# Altium schematic sheet SH5_BI-Gr_Potentiostat_ANA_proc_R0.05.00.SchDoc(SH5_BI-Gr_Potentiostat_ANA_proc_R0.05.00)
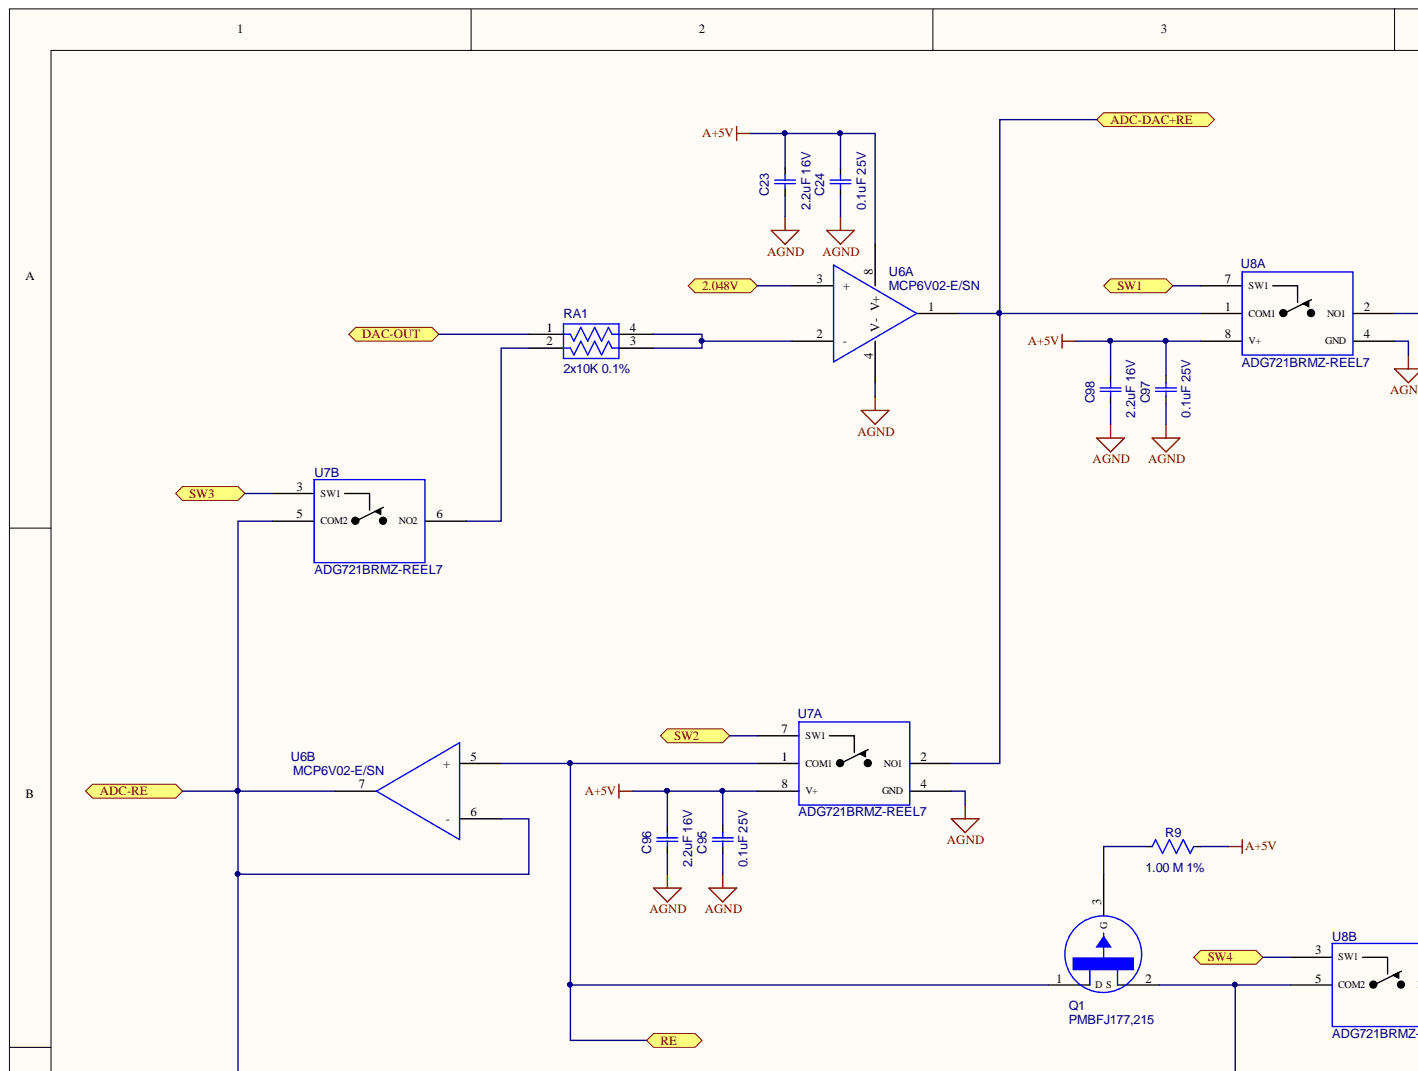
[diagram p0: SH5_BI-Gr_Potentiostat_ANA_proc_R0.05.00.SchDoc, top left]
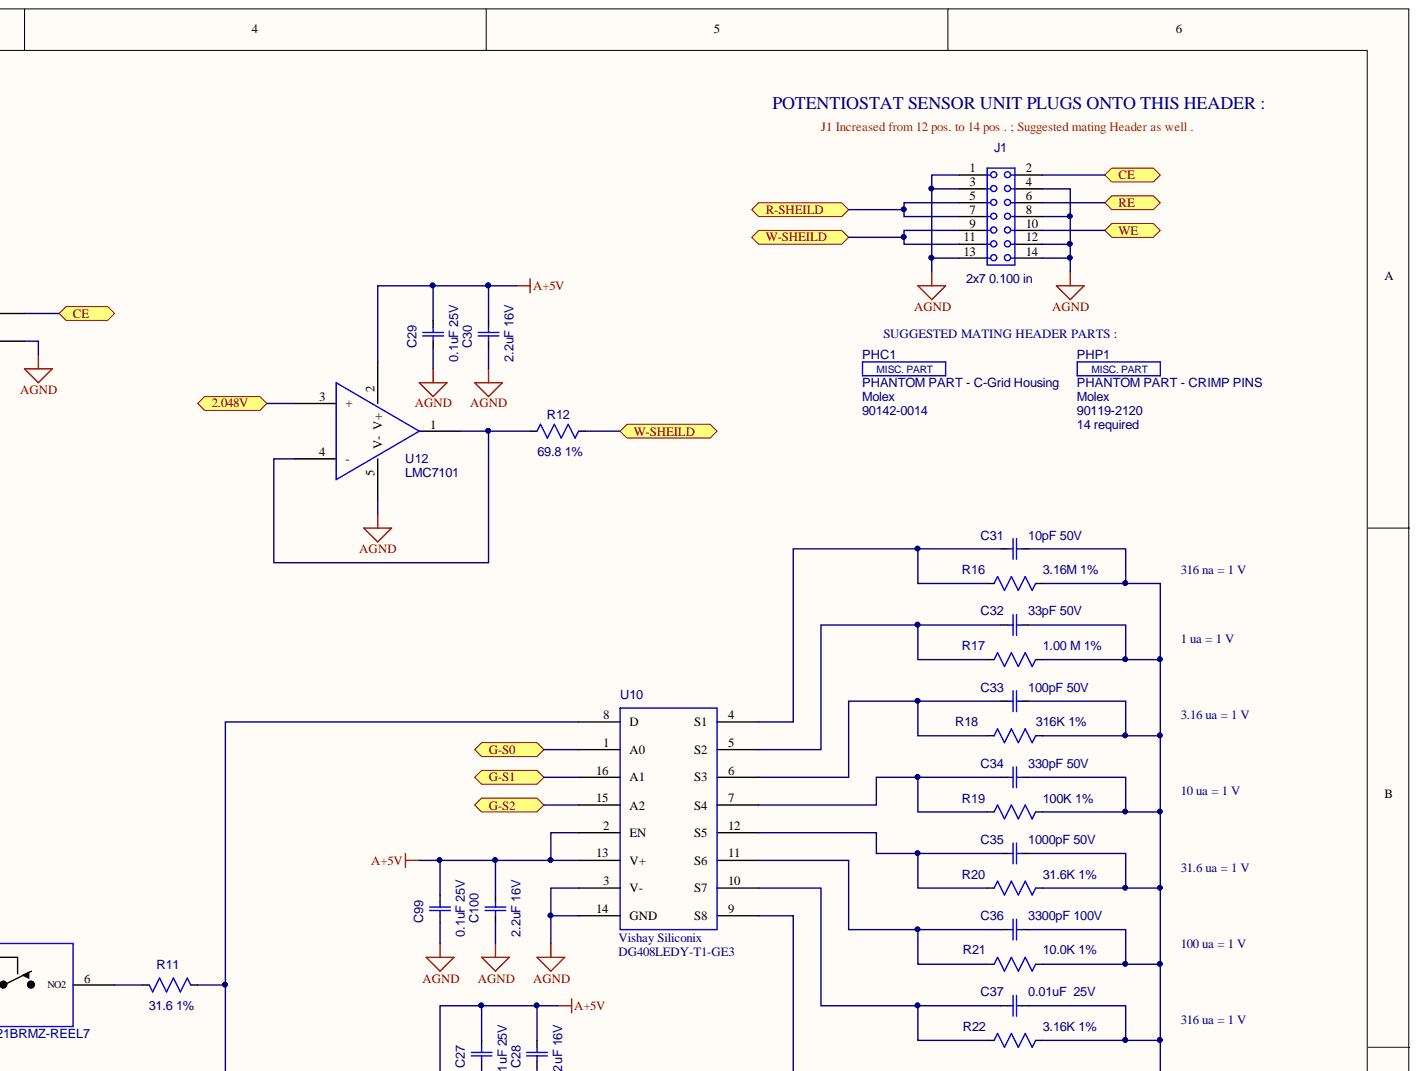
[diagram p1: SH5_BI-Gr_Potentiostat_ANA_proc_R0.05.00.SchDoc, top right]
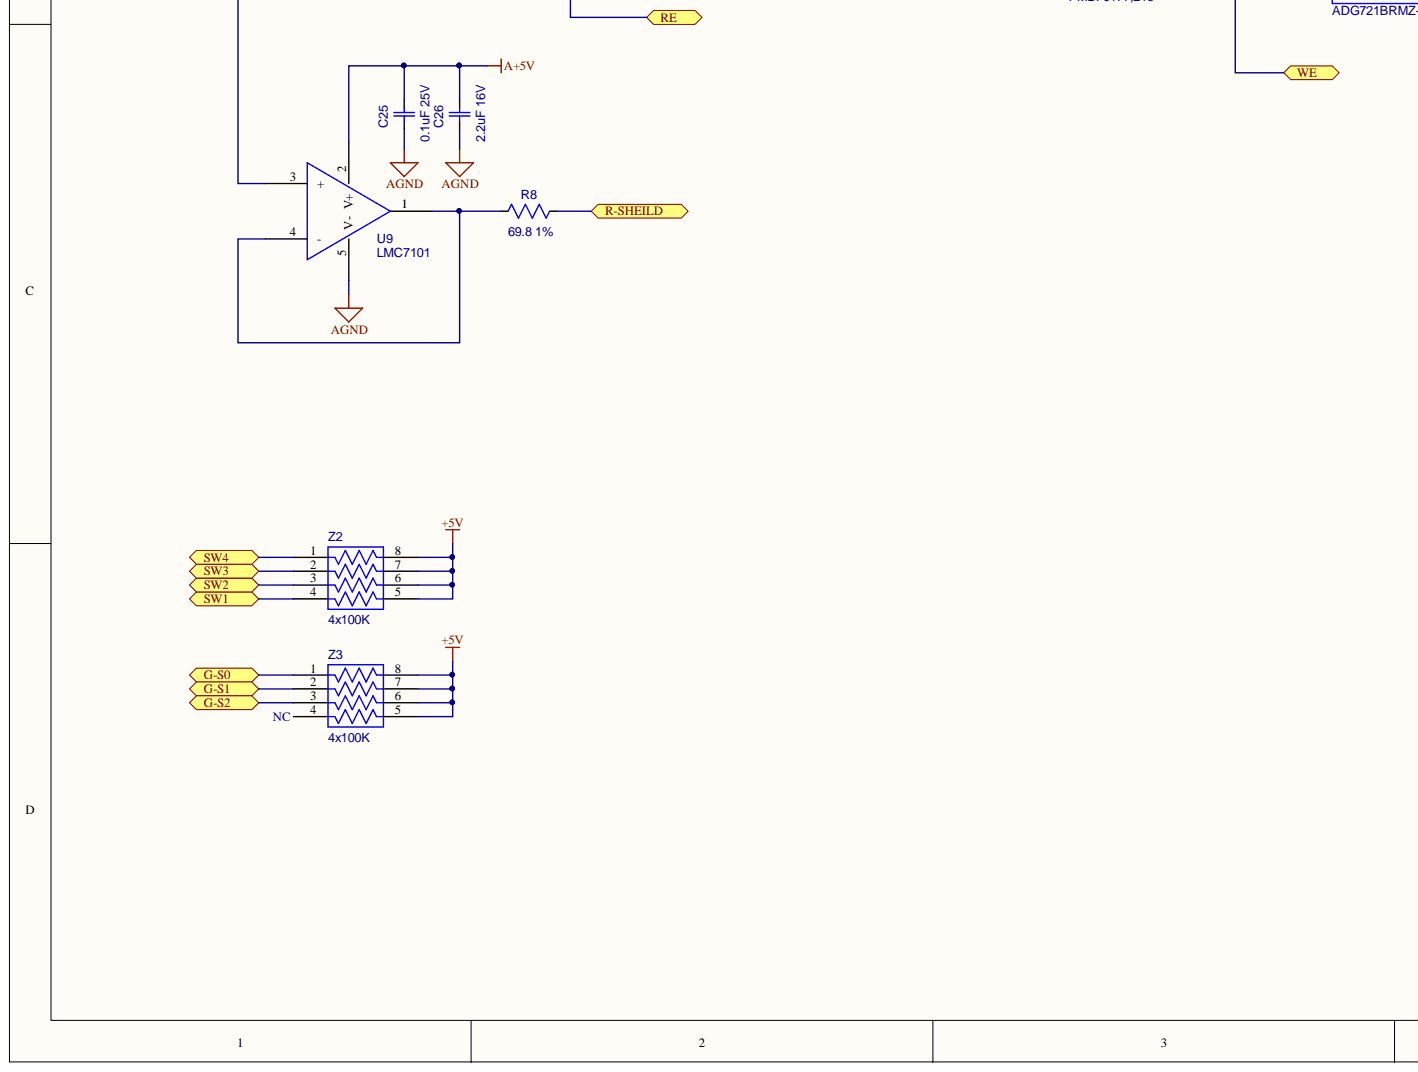
[diagram p2: SH5_BI-Gr_Potentiostat_ANA_proc_R0.05.00.SchDoc, bottom left]
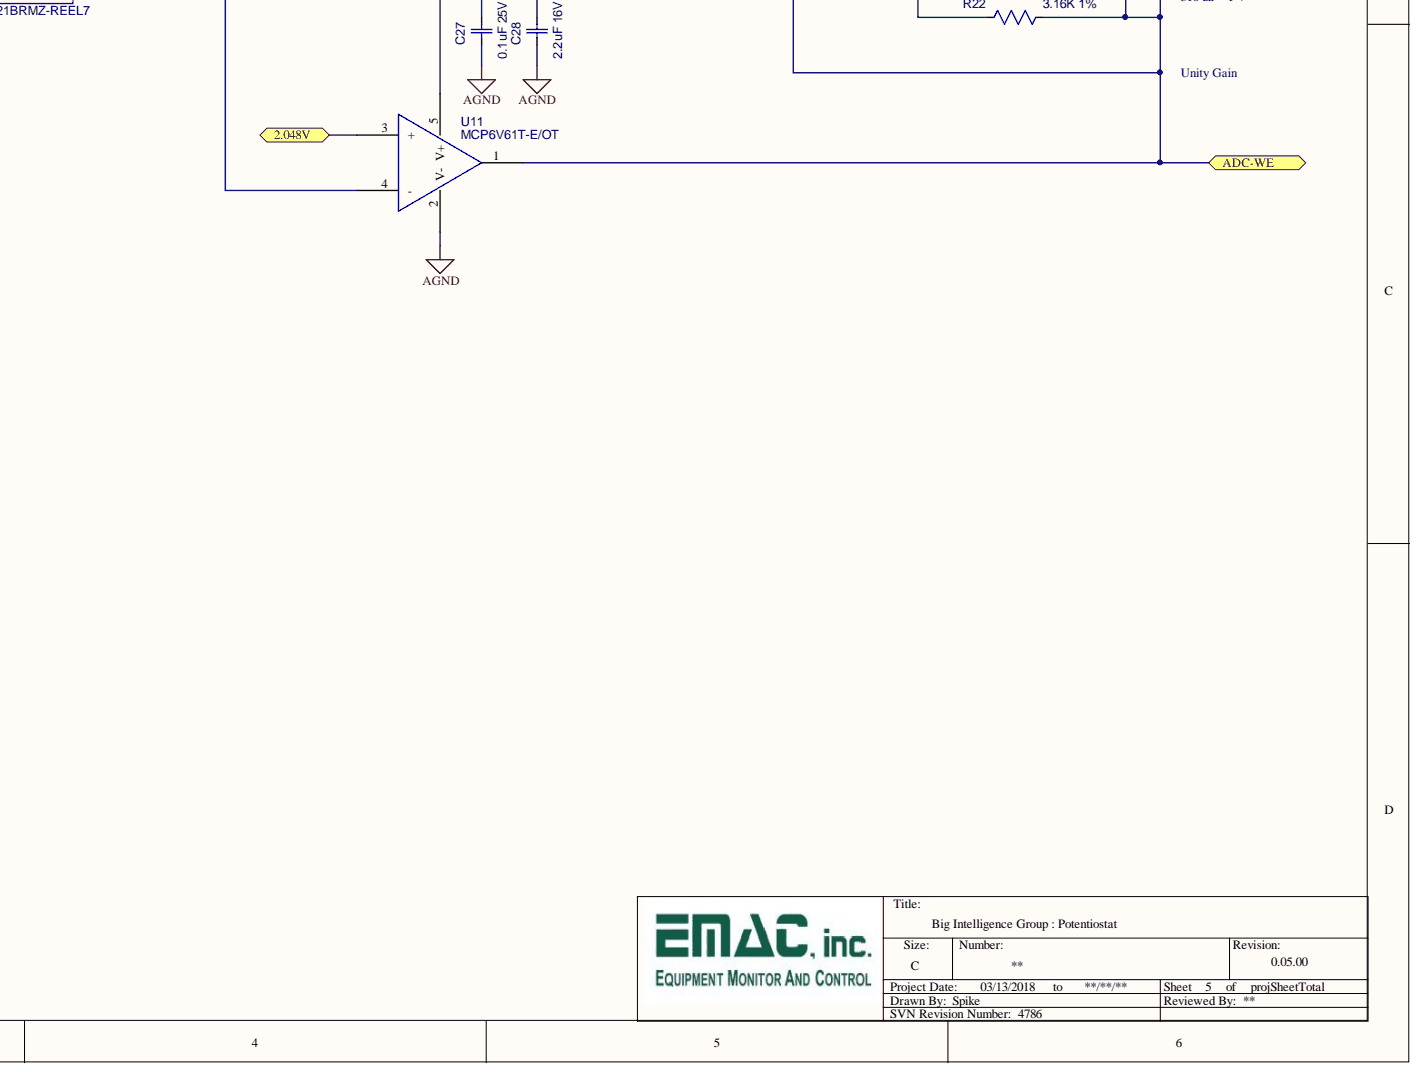
[diagram p3: SH5_BI-Gr_Potentiostat_ANA_proc_R0.05.00.SchDoc, bottom right]

## Parts
C23  2.2uF 16V  value 2.2uF  Notes: 16V  [CC0603_IPC_L]
C24  0.1uF 25V  Notes: 25V  [CC0603_IPC_L]
C25  0.1uF 25V  Notes: 25V  [CC0603_IPC_L]
C26  2.2uF 16V  value 2.2uF  Notes: 16V  [CC0603_IPC_L]
C27  0.1uF 25V  Notes: 25V  [CC0603_IPC_L]
C28  2.2uF 16V  value 2.2uF  Notes: 16V  [CC0603_IPC_L]
C29  0.1uF 25V  Notes: 25V  [CC0603_IPC_L]
C30  2.2uF 16V  value 2.2uF  Notes: 16V  [CC0603_IPC_L]
C31  10pF 50V  value 10pF  Notes: 50V  [CC0603_IPC_L]
C32  33pF 50V  value 33pF  Notes: 50V  [CC0603_IPC_L]
C33  100pF 50V  value 100pF  Notes: 50V  [CC0603_IPC_L]
C34  330pF 50V  value 330pF  Notes: 50V  [CC0603_IPC_L]
C35  1000pF 50V  value 1000pF  Notes: 50V  [CC0603_IPC_L]
C36  3300pF 100V  value 3300pF  Notes: 100V  [CC0603_IPC_L]
C37  0.01uF  25V  value 0.01uF  Notes: 25V  [CC0603_IPC_L]
C95  0.1uF 25V  Notes: 25V  [CC0603_IPC_L]
C96  2.2uF 16V  value 2.2uF  Notes: 16V  [CC0603_IPC_L]
C97  0.1uF 25V  Notes: 25V  [CC0603_IPC_L]
C98  2.2uF 16V  value 2.2uF  Notes: 16V  [CC0603_IPC_L]
C99  0.1uF 25V  Notes: 25V  [CC0603_IPC_L]
C100  2.2uF 16V  value 2.2uF  Notes: 16V  [CC0603_IPC_L]
J1  Notes: 2x7 0.100 in  [CHDR14_PAT2]  (hidden comment: CHDR14)
PHC1  PHANTOM PART - C-Grid Housing  Manfacturer PN: 90142-0014  Manfacturer: Molex  [PHANTOM_PAT1]
PHP1  PHANTOM PART - CRIMP PINS  Manfacturer PN: 90119-2120  Manfacturer: Molex  [PHANTOM_PAT1]
Q1  PMBFJ177,215  Type: S  [SOT95P230X110-3N]
R8  69.8 1%  [RC0603_IPC_L]
R9  1.00 M 1%  [RC0603_IPC_L]
R11  31.6 1%  [RC0603_IPC_L]
R12  69.8 1%  [RC0603_IPC_L]
R16  3.16M 1%  [RC0603_IPC_L]
R17  1.00 M 1%  [RC0603_IPC_L]
R18  316K 1%  [RC0603_IPC_L]
R19  100K 1%  [RC0603_IPC_L]
R20  31.6K 1%  [RC0603_IPC_L]
R21  10.0K 1%  [RC0603_IPC_L]
R22  3.16K 1%  [RC0603_IPC_L]
RA1  2x10K 0.1%  [RNET_ACAS0606_X2_PAT]
U6  MCP6V02  Manufacturer PN: MCP6V02-E/SN  [SOIC127P600X175-8N]  (parts A,B)
U7  ADG721  Manufacturer PN: ADG721BRMZ-REEL7  [SOP65P490X110-8N]  (parts A,B)
U8  ADG721  Manufacturer PN: ADG721BRMZ-REEL7  [SOP65P490X110-8N]  (parts A,B)
U9  LMC7101  [SOT23_5_LMC7101]
U10  DG408  Manufacturer PN: DG408LEDY-T1-GE3  Manufacturer: Vishay Siliconix  [SOIC127P600X165-16N]
U11  MCP6V61T-E/OT  [SOT23-5_MCP6V61]
U12  LMC7101  [SOT23_5_LMC7101]
Z2  4x100K  [RNET_CAT10-0804_X4_PAT]
Z3  4x100K  [RNET_CAT10-0804_X4_PAT]

## Ports
2.048V, ADC-DAC+RE, ADC-RE, ADC-WE, CE, DAC-OUT, G-S0, G-S1, G-S2, R-SHEILD, RE, SW1, SW2, SW3, SW4, W-SHEILD, WE

## Nets
+5V: Z2.5 Z2.6 Z2.7 Z2.8 Z3.5 Z3.6 Z3.7 Z3.8
A+5V: C23.1 C24.1 C25.1 C26.1 C27.1 C28.1 C29.1 C30.1 C95.1 C96.1 C97.1 C98.1 C99.1 C100.1 R9.2 U6.8(V+) U7.8(V+) U8.8(V+) U9.2(V+) U10.2(EN) U10.13(V+) U11.5(V+) U12.2(V+)
AGND: C23.2 C24.2 C25.2 C26.2 C27.2 C28.2 C29.2 C30.2 C95.2 C96.2 C97.2 C98.2 C99.2 C100.2 J1.1 J1.3 J1.4 J1.8 J1.12 J1.13 J1.14 U6.4(V-) U7.4(GND) U8.4(GND) U9.5(V-) U10.3(V-) U10.14(GND) U11.2(V-) U12.5(V-)
2.048V: U6.3(+) U11.3(+) U12.3(+) ; port 2.048V
ADC-DAC+RE: U6.1 U7.2(NO1) U8.1(COM1) ; port ADC-DAC+RE
ADC-RE: U6.6(-) U6.7 U7.5(COM2) U9.3(+) ; port ADC-RE
ADC-WE: C31.2 C32.2 C33.2 C34.2 C35.2 C36.2 C37.2 R16.2 R17.2 R18.2 R19.2 R20.2 R21.2 R22.2 U10.9(S8) U11.1 ; port ADC-WE
CE: J1.2 U8.2(NO1) ; port CE
DAC-OUT: RA1.1 ; port DAC-OUT
G-S0: U10.1(A0) Z3.1 ; port G-S0
G-S1: U10.16(A1) Z3.2 ; port G-S1
G-S2: U10.15(A2) Z3.3 ; port G-S2
R-SHEILD: J1.5 J1.7 R8.2 ; port R-SHEILD
RE: J1.6 Q1.1 U6.5(+) U7.1(COM1) ; port RE
SW1: U8.7(SW1) Z2.4 ; port SW1
SW2: U7.7(SW1) Z2.3 ; port SW2
SW3: U7.3(SW1) Z2.2 ; port SW3
SW4: U8.3(SW1) Z2.1 ; port SW4
W-SHEILD: J1.9 J1.11 R12.2 ; port W-SHEILD
WE: J1.10 Q1.2 U8.5(COM2) ; port WE
NetC31_1: C31.1 R16.1 U10.4(S1)
NetC32_1: C32.1 R17.1 U10.5(S2)
NetC33_1: C33.1 R18.1 U10.6(S3)
NetC34_1: C34.1 R19.1 U10.7(S4)
NetC35_1: C35.1 R20.1 U10.12(S5)
NetC36_1: C36.1 R21.1 U10.11(S6)
NetC37_1: C37.1 R22.1 U10.10(S7)
NetQ1_3: Q1.3 R9.1
NetR8_1: R8.1 U9.1 U9.4(-)
NetR11_1: R11.1 U10.8(D) U11.4(-)
NetR11_2: R11.2 U8.6(NO2)
NetR12_1: R12.1 U12.1 U12.4(-)
NetRA1_2: RA1.2 U7.6(NO2)
NetRA1_3: RA1.3 RA1.4 U6.2(-)

Not connected: Z3 4

## Library data of the parts
C23 C26 C28 C30 C96 C98 C100 = 09-90070: CCG^  2.2uF  16V 0603  10% X5R; type: S; SVN Revision No.: 5061; Status Code: L; Options: 00; Database Table Name: passives; Part Number: 09-90070; Package: 0603; msl: 1; Library Name: MySQL_ALTIUM.DbLib; Height Max (mm): 0.90 mm; Component Kind: Standard; Author: Mike L.
C24 C25 C27 C29 C95 C97 C99 = 09-90081: CCG^0.1uF   25V 0603   10% X7R; Manufacturer PN: C0603C104K3RAC7411; Manufacturer: Kemet; Value: .1 uF; type: S; Temp Range (°C): -55°C ~ 125°C; SVN Revision No.: 5061; Status Code: L; Options: 00; Database Table Name: passives; RoHS: YES; Part Number: 09-90081; Package: 0603; msl: 1; Library Name: MySQL_ALTIUM.DbLib; Height Max (mm): 0.90 mm; Component Kind: Standard; Author: Mike L.
C31 = 09-90019: CCG^10pF   50V 0603    5% C0G; type: S; SVN Revision No.: 5061; Status Code: L; Options: 00; Database Table Name: passives; Part Number: 09-90019; Package: 0603; msl: 1; Library Name: MySQL_ALTIUM.DbLib; Height Max (mm): 0.87 mm; Component Kind: Standard; Author: Mike L.
C32 = 09-90175: CCG^33pF 50V 0603 5% C0G; Manufacturer PN: CC0603JRNPO9BN330; Manufacturer: Yageo; type: S; Temp Range (°C): -55°C ~ 125°C; SVN Revision No.: 5061; Status Code: N; Options: 00; Database Table Name: passives; RoHS: YES; Part Number: 09-90175; Package: 0603; msl: 1; Library Name: MySQL_ALTIUM.DbLib; Last Updated: 11/27/17; Height Max (mm): 0.90 mm; Datasheet: http://www.yageo.com/documents/recent/UPY-GP_NP0_16V-to-50V_16.pdf; Component Kind: Standard; Author: JP
C33 = 09-90016: CCG^100pF   50V 0603    5% C0G; Manufacturer PN: C0603C101J5GACTU; Manufacturer: Kemet; type: S; Temp Range (°C): -55°C ~ 125°C; SVN Revision No.: 5061; Status Code: L; Options: 00; Database Table Name: passives; Part Number: 09-90016; Package: 0603; msl: 1; Library Name: MySQL_ALTIUM.DbLib; Height Max (mm): 0.87 mm; Component Kind: Standard; Author: Mike L.
C34 = 09-90023: CCG^330pF   50V 0603   10% X7R; type: S; SVN Revision No.: 5061; Status Code: L; Options: 00; Database Table Name: passives; Part Number: 09-90023; Package: 0603; msl: 1; Library Name: MySQL_ALTIUM.DbLib; Height Max (mm): 0.90 mm; Component Kind: Standard; Author: Mike L.
C35 = 09-90013: CCG^1000PF  50V 0603   10% X7R; Manufacturer PN: GRM188R71H102KA01D; Manufacturer: Murata; type: S; Temp Range (°C): -55°C ~ 125°C; SVN Revision No.: 5061; Status Code: L; Options: 00; Database Table Name: passives; RoHS: YES; Part Number: 09-90013; Package: 0603; msl: 1; Library Name: MySQL_ALTIUM.DbLib; Height Max (mm): 0.90 mm; Datasheet: http://search.murata.co.jp/Ceramy/image/img/w_hinm/L0005E.pdf; Component Kind: Standard; Author: Mike L.
C36 = 09-90037: CCG^3300pF 1000V 0603 5% X7R; Manufacturer PN: 06031C332JAT2A; Manufacturer: AVX Corporation; type: S; Temp Range (°C): -55°C ~ 125°C; SVN Revision No.: 5061; Status Code: N; Options: 00; Database Table Name: passives; RoHS: YES; Part Number: 09-90037; Package: 0603; msl: 1; Library Name: MySQL_ALTIUM.DbLib; Height Max (mm): 0.90 mm; Datasheet: http://datasheets.avx.com/X7RDielectric.pdf; Component Kind: Standard; Author: TM
C37 = 09-90060: CCG^0.01uF  25V 0603    5% C0G; Manufacturer PN: C1608C0G1E103J080AA; Manufacturer: TDK Corporation; type: S; Temp Range (°C): -55°C ~ 125°C; SVN Revision No.: 5061; Status Code: L; Options: 00; Database Table Name: passives; RoHS: YES; Part Number: 09-90060; Package: 0603; msl: 1; Library Name: MySQL_ALTIUM.DbLib; Height Max (mm): 0.95 mm; Component Kind: Standard; Author: Mike L.
J1 = 20-0314: NHD^MPE 2X7 0.1" GOLD PRE; Manufacturer: EMAC inc.; Value: {Value}; Temp Range (°C): {Temp Range}; SVN Revision No.: 558; Status Code: L; Options: 00; Database Table Name: connectors; Type: M; RoHS: YES; Part Number: 20-0314; MSL: N/A; Library Name: MySQL_ALTIUM.DbLib; Height Max (mm): 8.50 mm; Component Kind: Standard; ComponentHeight: {ComponentHeight}; Author: ML
PHC1 = 26: PHANTOM PART W/O PNP; Part Number: 00-NON-INVNTORY; SVN Revision No.: 2917; Options: 98; Library Name: MySQL_ALTIUM.DbLib; id: 26; Database Table Name: phantom; Component Kind: Standard; Author: {Author}
PHP1 = 26: PHANTOM PART W/O PNP; Part Number: 00-NON-INVNTORY; SVN Revision No.: 2917; Options: 98; Notes: 14 required; Library Name: MySQL_ALTIUM.DbLib; id: 26; Database Table Name: phantom; Component Kind: Standard; Author: {Author}
Q1 = 05-90036: TJP^30V 0.3W J177 SOT23; Manufacturer: NXP USA Inc.; Value: 30V, 300mW; Temp Range (°C): -65°C ~ 150°C; SVN Revision No.: VersionControl; Status Code: N; Options: 00; Notes: Vgs(off)=0.8V ~ 2.25V, Ids=1.5mA ~ 20mA, Rds(on) = 300ohm; Database Table Name: actives; ROHS: YES; Part Number: 05-90036; Package: SOT23-3; MSL: 1; Manufacturer PN: PMBFJ177,215; Library Name: MySQL_ALTIUM.DbLib; Height Max (mm): 1.10 mm; Datasheet: http://www.nxp.com/documents/data_sheet/PMBFJ174_175_176_177_CNV.pdf; Component Kind: Standard; Author: TM
R8 R12 = 10-90453: RCP^  69.8 ohm 1% 0.100W  0603; Manufacturer PN: RC0603FR-0769R8L; Manufacturer: Yageo; Temp Range (°C): -55°C ~ 155°C; SVN Revision No.: 3043; Status Code: N; Options: 00; Notes: Moisture Resistant Thick Film; Database Table Name: resistors; Value: 69.8 1%; Type: S; RoHS: YES; Part Number: 10-90453; Package: 0603; MSL: 1; Library Name: MySQL_ALTIUM.DbLib; Last Updated: 3/20/18; Height Max (mm): 0.55 mm; Datasheet: http://www.yageo.com/documents/recent/PYu-RC_Group_51_RoHS_L_9.pdf; Component Kind: Standard; Author: JP
R9 R17 = 10-90061: RCP^ 1M ohm 1% 0.100W 0603; Manufacturer PN: RC0603FR-071ML; Manufacturer: Yageo; Value: 1M 1%; Temp Range (°C): -55°C ~ 155°C; SVN Revision No.: 3043; Status Code: L; Options: 00; Database Table Name: resistors; Type: S; RoHS: YES; Part Number: 10-90061; Package: 0603; MSL: 1; Library Name: MySQL_ALTIUM.DbLib; Height Max (mm): 0.55 mm; Datasheet: http://www.yageo.com.tw/exep/pages/download/literatures/PYu-R_INT-thick_7.pdf; Component Kind: Standard; Author: Mike L.
R11 = 10-90455: RCP^  31.6 ohm 1% 0.100W  0603; Manufacturer PN: ERJ-3EKF31R6V; Manufacturer: Panasonic Electronic Components; Temp Range (°C): -55°C ~ 155°C; SVN Revision No.: 3043; Status Code: N; Options: 00; Notes: Automotive AEC-Q200; Database Table Name: resistors; Value: 31.6 1%; Type: S; RoHS: YES; Part Number: 10-90455; Package: 0603; MSL: 1; Library Name: MySQL_ALTIUM.DbLib; Last Updated: 3/26/18; Height Max (mm): 0.55 mm; Datasheet: https://industrial.panasonic.com/cdbs/www-data/pdf/RDA0000/AOA0000C304.pdf; Component Kind: Standard; Author: TM
R16 = 10-90458: RCP^ 3.16 Mohm 1% 0.100W 0603; Manufacturer PN: CRCW06033M16FKEA; Manufacturer: Vishay Dale; Temp Range (°C): -55°C ~ 155°C; SVN Revision No.: 3043; Status Code: N; Options: 00; Notes: Automotive AEC-Q200; Database Table Name: resistors; Value: 3.16M 1%; Type: S; RoHS: YES; Part Number: 10-90458; Package: 0603; MSL: 1; Library Name: MySQL_ALTIUM.DbLib; Last Updated: 3/26/18; Height Max (mm): 0.50 mm; Datasheet: http://www.vishay.com/docs/20035/dcrcwe3.pdf; Component Kind: Standard; Author: JP
R18 = 10-90457: RCP^ 316 Kohm 1% 0.100W 0603; Manufacturer PN: RC0603FR-07316KL; Manufacturer: Yageo; Temp Range (°C): -55°C ~ 155°C; SVN Revision No.: 3043; Status Code: N; Options: 00; Notes: Moisture Resistant; Database Table Name: resistors; Value: 316K 1%; Type: S; RoHS: YES; Part Number: 10-90457; Package: 0603; MSL: 1; Library Name: MySQL_ALTIUM.DbLib; Last Updated: 3/26/18; Height Max (mm): 0.55 mm; Datasheet: http://www.yageo.com/documents/recent/PYu-RC_Group_51_RoHS_L_9.pdf; Component Kind: Standard; Author: JP
R19 = 10-90076: RCP^ 100K ohm 1% 0.100W 0603; Manufacturer PN: RC0603FR-07100KL; SVN Revision No.: 3043; Status Code: L; Options: 00; Database Table Name: resistors; Value: 100K 1%; Type: S; Part Number: 10-90076; Package: 0603; MSL: 1; Library Name: MySQL_ALTIUM.DbLib; Height Max (mm): 0.55 mm; Component Kind: Standard; Author: Mike L.
R20 = 10-90456: RCP^  31.6Kohm 1% 0.100W  0603; Manufacturer PN: RC0603FR-0731K6L; Manufacturer: Yageo; Temp Range (°C): -55°C ~ 155°C; SVN Revision No.: 3043; Status Code: N; Options: 00; Notes: Moisture Resistant; Database Table Name: resistors; Value: 31.6K 1%; Type: S; RoHS: YES; Part Number: 10-90456; Package: 0603; MSL: 1; Library Name: MySQL_ALTIUM.DbLib; Last Updated: 3/26/18; Height Max (mm): 0.55 mm; Datasheet: http://www.yageo.com/documents/recent/PYu-RC_Group_51_RoHS_L_9.pdf; Component Kind: Standard; Author: TM
R21 = 10-90107: RCP_ 10.0K ohm 1% 0.062W  0603; Manufacturer PN: RC0603FR-0710KL; Manufacturer: Yageo; Temp Range (°C): -55°C ~ 155°C; SVN Revision No.: 3043; Status Code: L; Options: 00; Database Table Name: resistors; Value: 10.0K 1%; Type: S; RoHS: YES; Part Number: 10-90107; Package: 0603; MSL: 1; Library Name: MySQL_ALTIUM.DbLib; Height Max (mm): 0.55 mm; Datasheet: http://www.yageo.com.tw/exep/pages/download/literatures/PYu-R_INT-thick_7.pdf; Component Kind: Standard; Author: Mike L.
R22 = 10-90181: RCP_ 3.16K OHM 1% 0.100W  0603; SVN Revision No.: 3043; Status Code: L; Options: 00; Database Table Name: resistors; Value: 3.16K 1%; Type: S; Part Number: 10-90181; Package: 0603; MSL: 1; Library Name: MySQL_ALTIUM.DbLib; Height Max (mm): 0.55 mm; Component Kind: Standard; Author: Mike L.
RA1 = 10-90459: RNI^ 2x10Kohm 0.1% 0.10W 0606; Manufacturer PN: ACASN1002S1002P1AT; Manufacturer: Vishay Beyschlag; Temp Range (°C): -55°C ~ 155°C; SVN Revision No.: 4687; Status Code: N; Options: 00; Notes: Automotive AEC-Q200, Voltage Divider (TCR Matched); Database Table Name: resistors; Value: 2x10K 0.1%; Type: S; RoHS: YES; Part Number: 10-90459; Package: 0606; MSL: 1; Library Name: MySQL_ALTIUM.DbLib; Height Max (mm): 0.55 mm; Datasheet: http://www.vishay.com/docs/28770/acasat.pdf; Component Kind: Standard; Author: TM
U6 = 06-90640: UAN^OA 2x0DR RRO MCP6V02 SOIC8; Manufacturer: Microchip Technology; Temp Range (°C): -40°C ~ 125°C; SVN Revision No.: 3060; Status Code: N; Options: 00; Notes: Vs=1.8V ~ 5.5V, GBP=1.3MHz; Database Table Name: ics; Type: S; RoHS: YES; Part Number: 06-90640; Package: SOIC-8; MSL: 1; Library Name: MySQL_ALTIUM.DbLib; Last Updated: 3/26/18; Height Max (mm): 1.75 mm; Datasheet: http://www.microchip.com/mymicrochip/filehandler.aspx?ddocname=en535978; Component Kind: Standard; Author: JP
U7 U8 = 06-90639: UAN^AX 2xSPST-NO ADG721 MSOP8; Manufacturer: Analog Devices Inc.  Analog Devices Inc.; Temp Range (°C): -40°C ~ 85°C; SVN Revision No.: 4673; Status Code: N; Options: 00; Notes: Ron=4Ohm, Vs=1.8V ~ 5.5V,; Database Table Name: ics; Type: S; RoHS: YES; Part Number: 06-90639; Package: MSOP-8; MSL: 1; Library Name: MySQL_ALTIUM.DbLib; Last Updated: 3/26/18; Datasheet: http://www.analog.com/media/en/technical-documentation/data-sheets/ADG721_722_723.pdf; Component Kind: Standard; Author: JP
U9 U12 = 06-90364: UAN^OA 1/R-R 3-12V     SOT23-5; Part Number: {Part Number}; Manufacturer PN: LMC7101BYM5; Manufacturer: Micrel; Value: {Value}; Temp Range (°C): -40°C ~ 85°C; SVN Revision No.: 2702; Status Code: L; Options: 00; Notes: Vs=	2.7 V ~ 12 V, ±1.35 V ~ 6 V, Is=	800µA; ManfacturerPN: {ManfacturerPN}; Database Table Name: ics; Type: S; RoHS: YES; Part Number: 06-90364; Package: SOT23-5; MSL: 1; Library Name: MySQL_ALTIUM.DbLib; Last Updated: 9/15/16; Height Max (mm): 1.45 mm; Datasheet: http://www.micrel.com/_PDF/lmc7101.pdf; Component Kind: Standard; ComponentHeight: {ComponentHeight}; Author: ML
U10 = 06-90642: UAN^AX 1of8mux DG408 SOIC16; Temp Range (°C): -40°C ~ 85°C; SVN Revision No.: 4682; Status Code: N; Options: 00; Notes: Vs(+)=3V ~ 16V, Vs(±) = ±3V ~ 8V, RDS(on)=23 ohm, Vinh = 2.4V, Vinl= 0.8V; Database Table Name: ics; Type: S; RoHS: YES; Part Number: 06-90642; Package: SOIC-16; MSL: 1; Library Name: MySQL_ALTIUM.DbLib; Height Max (mm): 1.75 mm; Datasheet: http://www.vishay.com/docs/78084/dg408le.pdf; Component Kind: Standard; Author: TM
U11 = 06-90641: UAN^OA 1x0DR MCP6V61 SOT23-5; Manufacturer: Microchip Technology; Temp Range (°C): -40°C ~ 125°C; SVN Revision No.: 4680; Status Code: N; Options: 00; Notes: Vs=1.8V ~ 5.5V, GBP=1MHz, Iout=23mA, Is=80uA; Database Table Name: ics; Type: S; RoHS: YES; Part Number: 06-90641; Package: SOT23-5; MSL: 1; Manufacturer PN: MCP6V61T-E/OT; Library Name: MySQL_ALTIUM.DbLib; Height Max (mm): 1.45 mm; Datasheet: http://www.microchip.com/mymicrochip/filehandler.aspx?ddocname=en572896; Component Kind: Standard; Author: TM
Z2 Z3 = 10-90234: RNI^ 4x100K ohm 5% 0.031W 0804; Manufacturer PN: CAT10-104J4LF; Manufacturer: Bourns Inc.; Temp Range (°C): -55°C ~ 125°C; SVN Revision No.: 2500; Status Code: L; Options: 00; Database Table Name: resistors; Value: 4x100K; Type: S; RoHS: YES; Part Number: 10-90234; Package: 0804; MSL: 1; Library Name: MySQL_ALTIUM.DbLib; Height Max (mm): 0.55 mm; Datasheet: http://www.bourns.com/docs/Product-Datasheets/CAT10.pdf; Component Kind: Standard; Author: Mike L.
